# Altium schematic sheet POWER.SchDoc(POWER)
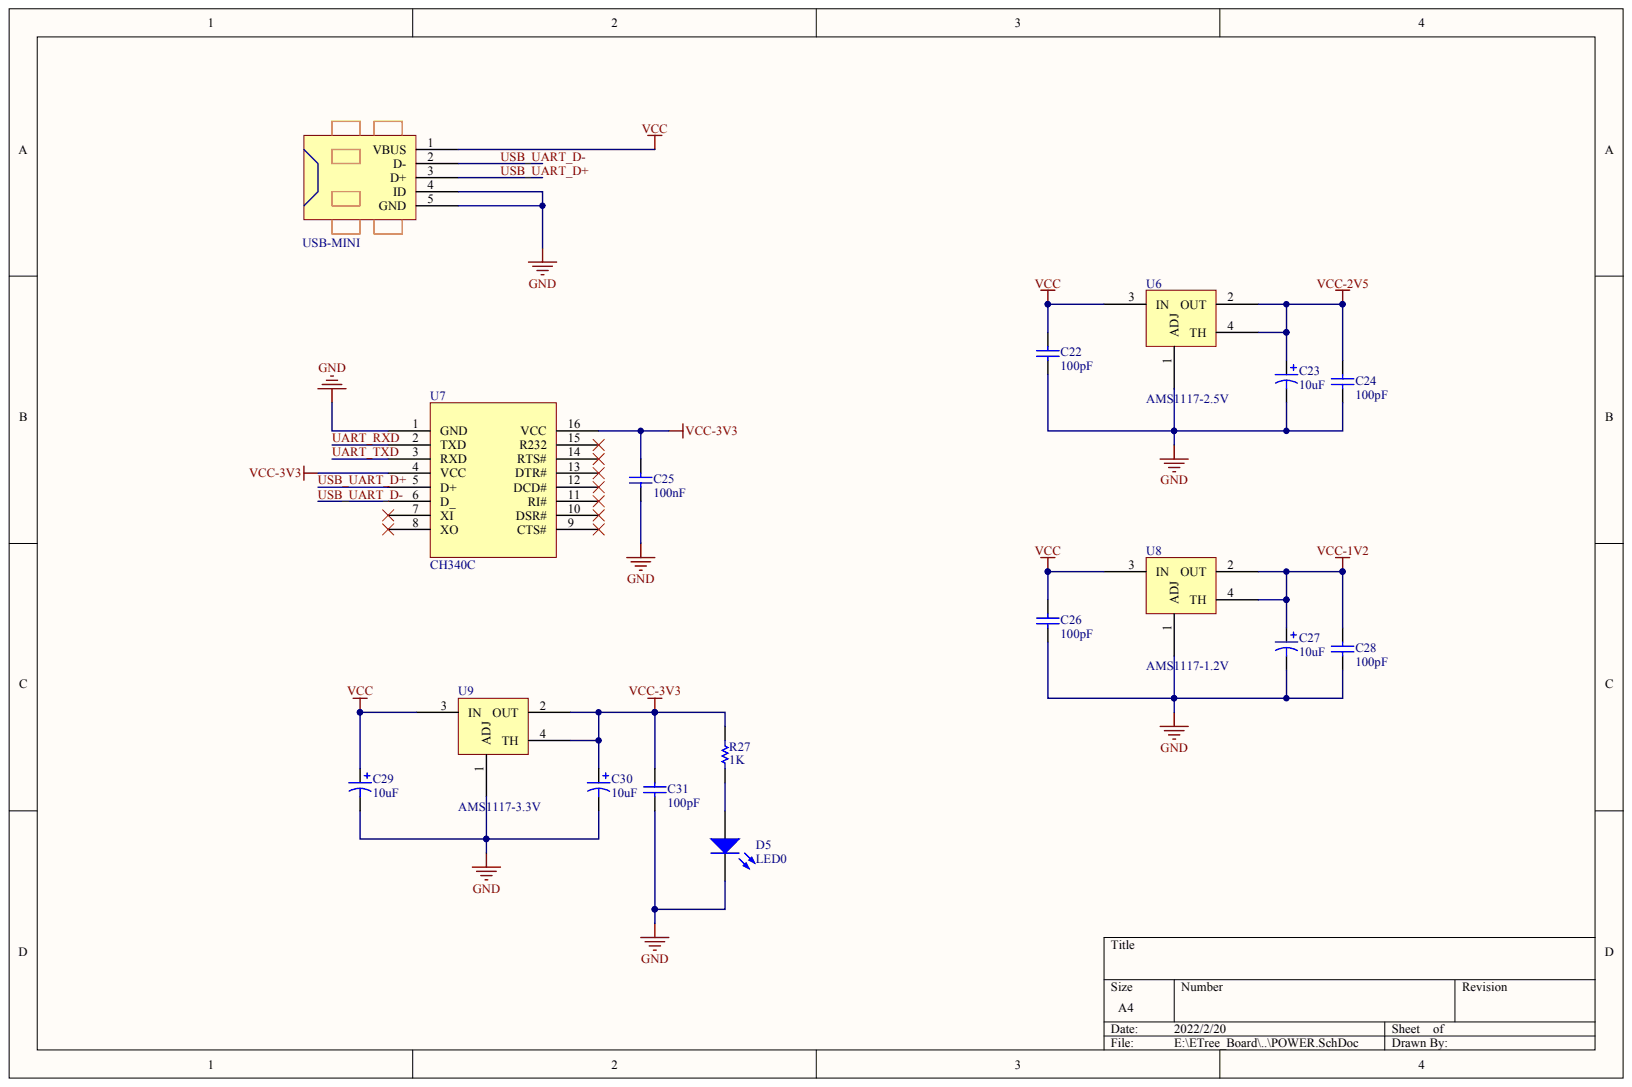
[diagram p0: POWER.SchDoc]

## Parts
C22  value 100pF  (hidden comment: Cap)
C23  value 10uF  (hidden comment: Cap Pol1)
C24  value 100pF  (hidden comment: Cap)
C25  value 100nF  (hidden comment: Cap)
C26  value 100pF  (hidden comment: Cap)
C27  value 10uF  (hidden comment: Cap Pol1)
C28  value 100pF  (hidden comment: Cap)
C29  value 10uF  (hidden comment: Cap Pol1)
C30  value 10uF  (hidden comment: Cap Pol1)
C31  value 100pF  (hidden comment: Cap)
D5  LED0
R27  value 1K  (hidden comment: Res1)
U6  AMS1117-2.5V
U7  CH340C
U8  AMS1117-1.2V
U9  AMS1117-3.3V
USB-MINI1  USB-MINI

## Nets
GND: C22.1 C23.2 C24.1 C25.2 C26.1 C27.2 C28.1 C29.2 C30.2 C31.1 D5.2 U6.1(ADJ) U7.1(GND) U8.1(ADJ) U9.1(ADJ) USB-MINI1.4(ID) USB-MINI1.5(GND)
VCC: C22.2 C26.2 C29.1 U6.3(IN) U8.3(IN) U9.3(IN) USB-MINI1.1(VBUS)
VCC-1V2: C27.1 C28.2 U8.2(OUT) U8.4(TH)
VCC-2V5: C23.1 C24.2 U6.2(OUT) U6.4(TH)
VCC-3V3: C25.1 C30.1 C31.2 R27.2 U7.4(VCC) U7.16(VCC) U9.2(OUT) U9.4(TH)
UART_RXD: U7.2(TXD)
UART_TXD: U7.3(RXD)
USB_UART_D+: U7.5(D+) USB-MINI1.3(D+)
USB_UART_D-: U7.6(D_) USB-MINI1.2(D-)
NetD5_1: D5.1 R27.1

Not connected: U7 7(XI) 8(XO) 9(CTS#) 10(DSR#) 11(RI#) 12(DCD#) 13(DTR#) 14(RTS#) 15(R232)

## Library data of the parts
C22-C31 D5 R27 U6-U9 USB-MINI1 = (no symbol name)
  C22 C24-C26 C28 C31: Published: 8-Jun-2000; LatestRevisionDate: 17-Jul-2002; LatestRevisionNote: Re-released for DXP Platform.; PackageReference: RAD-0.3; PackageDescription: Radial Cap, Thru-Hole; 2 Leads; 0.3 in Pin Spacing
  C23 C27 C29 C30: Published: 8-Jun-2000; LatestRevisionDate: 17-Jul-2002; LatestRevisionNote: Re-released for DXP Platform.; PackageReference: RB7.6-15; PackageDescription: Polarized Capacitor (Radial); 2 Leads
  D5: Published: 8-Jun-2000; LatestRevisionDate: 08-Jul-2005; LatestRevisionNote: Mech Layer 1 removed.; PackageReference: LED-0; PackageDescription: LED; 2 Leads
  R27: Published: 8-Jun-2000; LatestRevisionDate: 17-Jul-2002; LatestRevisionNote: Re-released for DXP Platform.; PackageReference: AXIAL-0.3; PackageDescription: Axial Device, Thru-Hole; 2 Leads; 0.3 in Pin Spacing
  C22-C31 D5 R27: Publisher: Altium Limited
